# Altium schematic sheet ESP32.SchDoc
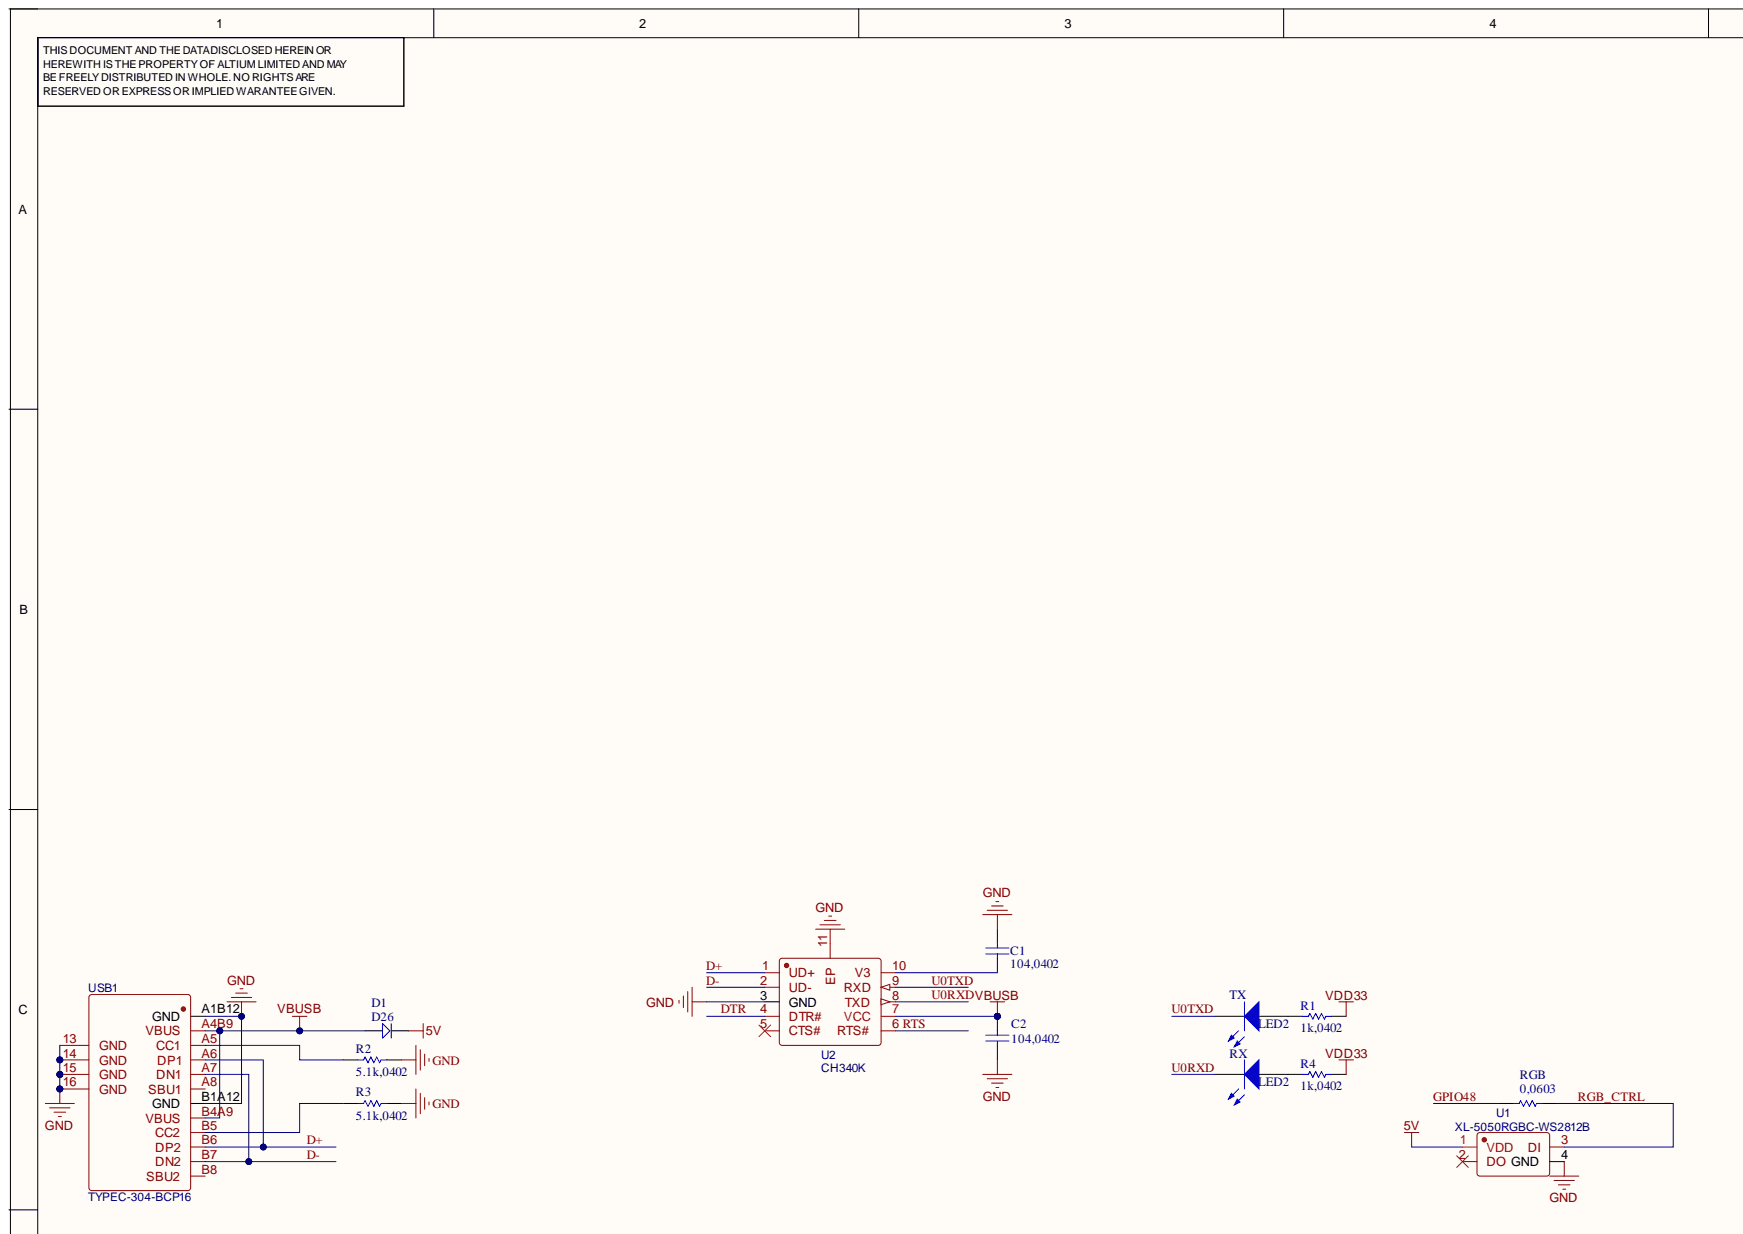
[diagram p0: ESP32.SchDoc, top left]
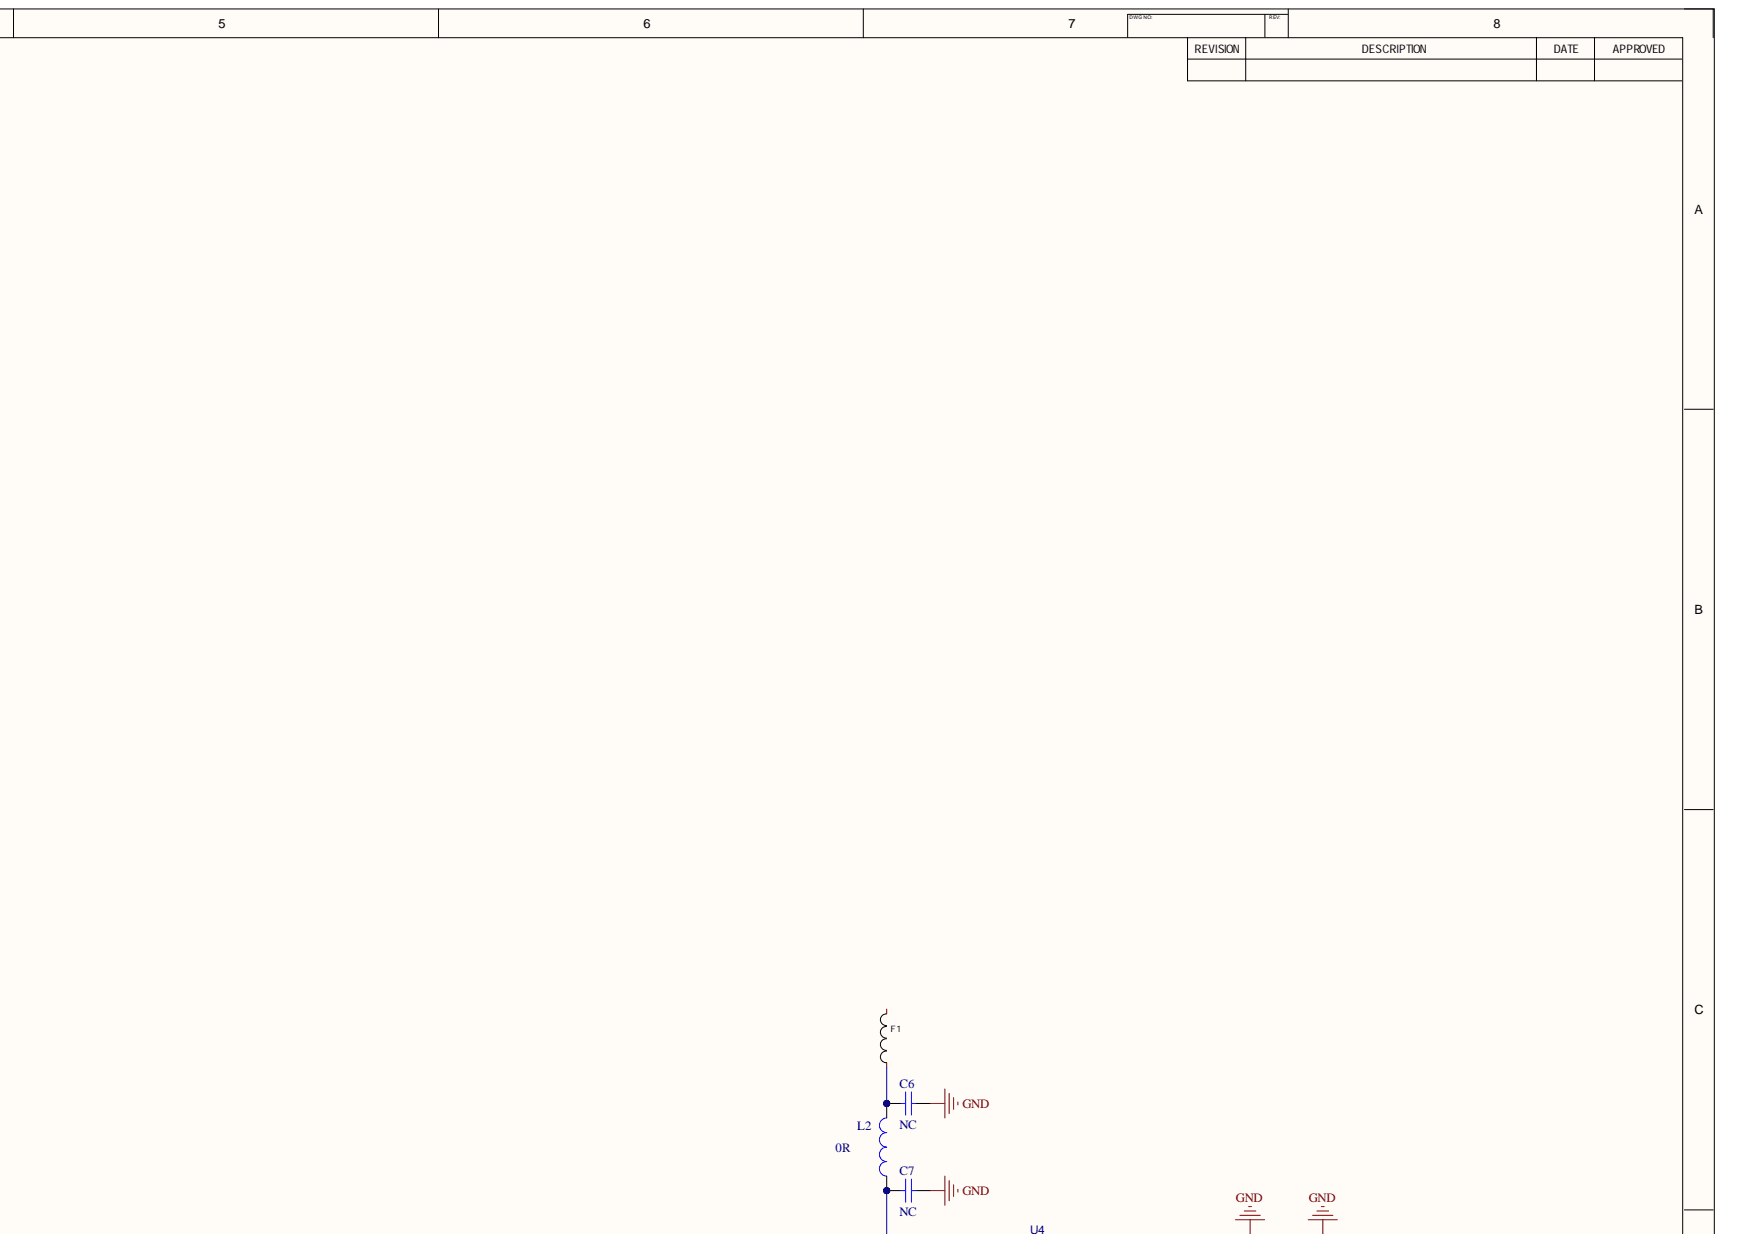
[diagram p1: ESP32.SchDoc, top right]
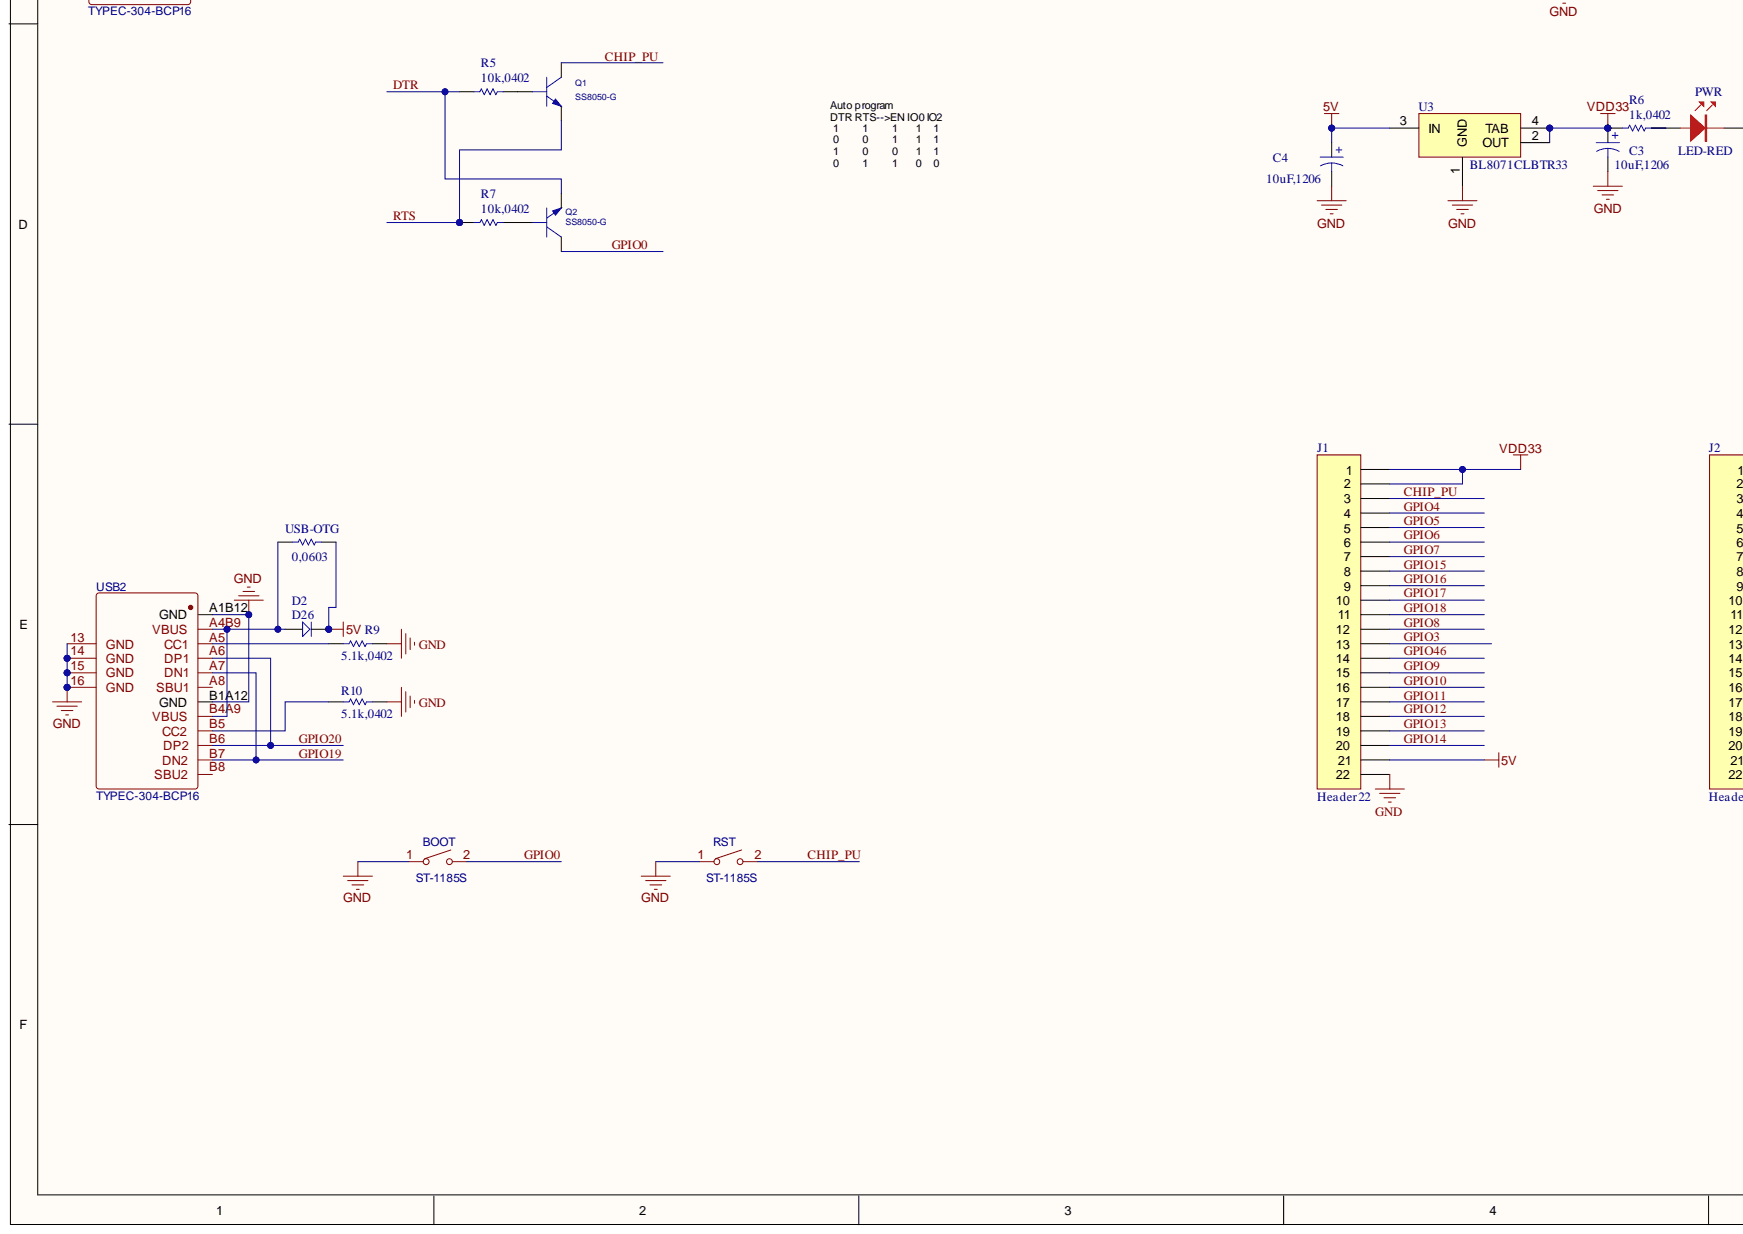
[diagram p2: ESP32.SchDoc, bottom left]
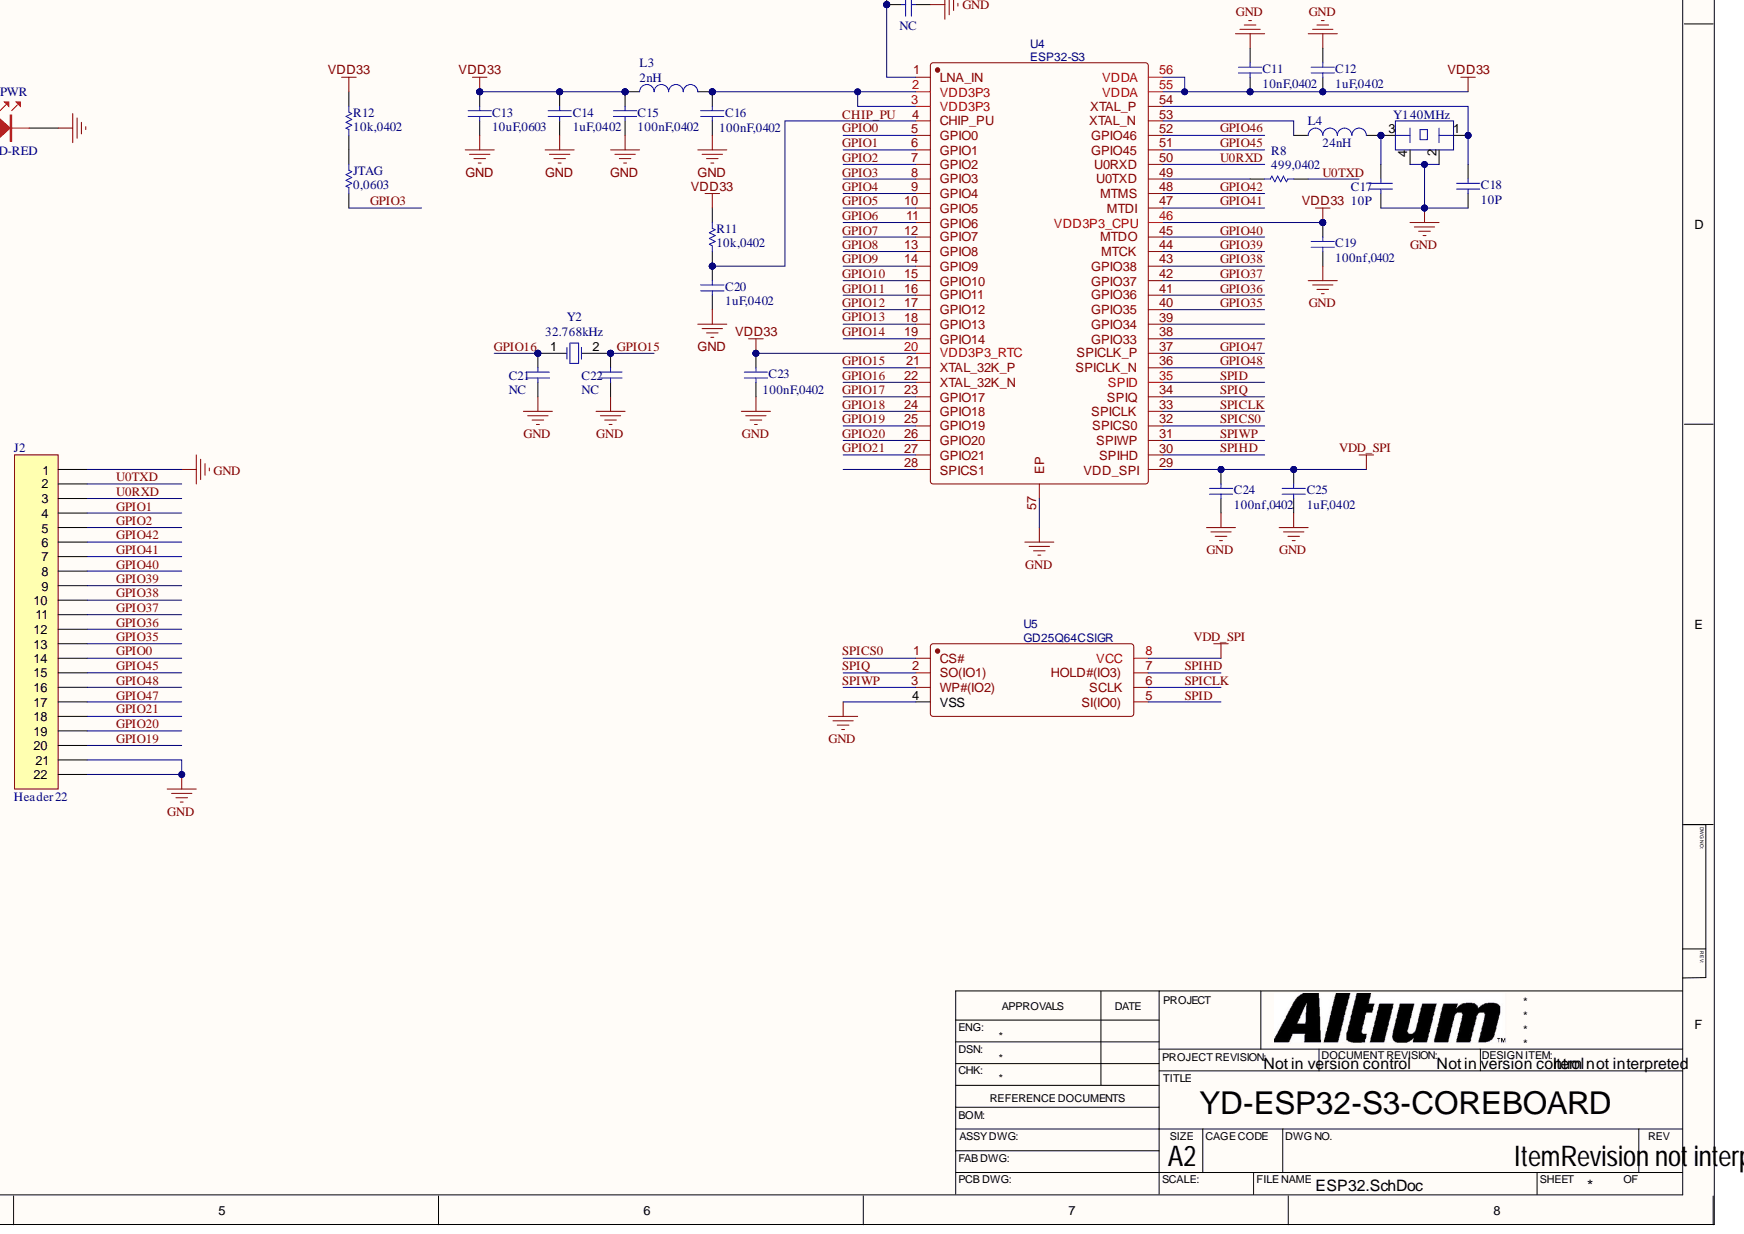
[diagram p3: ESP32.SchDoc, bottom right]

## Parts
BOOT  ST-1185S  [SW-SMD_ST-1185S]
C1  104,0402  [C0402]
C2  104,0402  [C0402]
C3  10uF,1206  [A]
C4  10uF,1206  [a]
C6  NC  [C0402]
C7  NC  [C0402]
C11  10nF,0402  [C0402]
C12  1uF,0402  [C0402]
C13  10uF,0603  [C0603]
C14  1uF,0402  [C0402]
C15  100nF,0402  [C0402]
C16  100nF,0402  [C0402]
C17  10P  [C0402]
C18  10P  [C0402]
C19  100nf,0402  [C0402]
C20  1uF,0402  [C0402]
C21  NC  [C0402]
C22  NC  [C0402]
C23  100nF,0402  [C0402]
C24  100nf,0402  [C0402]
C25  1uF,0402  [C0402]
D1  D26  [SOD-123FL]
D2  D26  [SOD-123FL]
F1  RFANT5220110A2T  [ANT-SMD_L5.2-W2.0]  (value not drawn)
J1  Header 22  [HDR1X22]
J2  Header 22  [HDR1X22]
JTAG  0,0603  [SB_0603]
L2  value 0R  [R0402]  (hidden comment: Inductor)
L3  value 2nH  [R0402]  (hidden comment: Inductor)
L4  value 24nH  [R0402]  (hidden comment: Inductor)
PWR  LED-RED  [LED0603]
Q1  SS8050-G  [SOT23]
Q2  SS8050-G  [SOT23]
R1  1k,0402  [R0402]
R2  5.1k,0402  [R0402]
R3  5.1k,0402  [R0402]
R4  1k,0402  [R0402]
R5  10k,0402  [R0402]
R6  1k,0402  [R0402]
R7  10k,0402  [R0402]
R8  499,0402  [R0402]
R9  5.1k,0402  [R0402]
R10  5.1k,0402  [R0402]
R11  10k,0402  [R0402]
R12  10k,0402  [R0402]
RGB  0,0603  [SB_0603]
RST  ST-1185S  [SW-SMD_ST-1185S]
RX  LED2  [LED0603]
TX  LED2  [LED0603]
U1  XL-5050RGBC-WS2812B  [LED-SMD_4P-L5.0-W5.0-BL_XL-5050RGBC]
U2  CH340K  [ESOP-10_L4.9-W3.9-P1.00-LS6.2-BL-EP]
U3  BL8071CLBTR33  [SOT-23]
U4  ESP32-S3  [QFN-56_L7.0-W7.0-P0.40-TL-EP4.0]
U5  GD25Q64CSIGR  [SOP-8_L5.3-W5.3-P1.27-LS8.0-BL]
USB1  TYPEC-304-BCP16  [USB-C-SMD_TYPEC-304-BCP16]
USB2  TYPEC-304-BCP16  [USB-C-SMD_TYPEC-304-BCP16]
USB-OTG  0,0603  [SB_0603]
Y1  40MHz  [EPSON_TSX-3225]
Y2  32.768kHz  [FC-135R_L3.2-W1.5]

## Nets
5V: C4.1 D1.2 D2.2 J1.21 U1.1(VDD) U3.3(IN) USB-OTG.1
GND: BOOT.1 C1.2 C2.2 C3.2 C4.2 C6.1 C7.1 C11.2 C12.2 C13.1 C14.1 C15.1 C16.1 C17.1 C18.1 C19.1 C20.1 C21.1 C22.1 C23.1 C24.1 C25.1 J1.22 J2.1 J2.21 J2.22 PWR.K R2.2 R3.2 R9.2 R10.2 RST.1 U1.4(GND) U2.3(GND) U2.11(EP) U3.1(GND) U4.57(EP) U5.4(VSS) USB1.13(GND) USB1.14(GND) USB1.15(GND) USB1.16(GND) USB1.A1B12(GND) USB1.B1A12(GND) USB2.13(GND) USB2.14(GND) USB2.15(GND) USB2.16(GND) USB2.A1B12(GND) USB2.B1A12(GND) Y1.2 Y1.4
VBUSB: C2.1 D1.1 U2.7(VCC) USB1.A4B9(VBUS) USB1.B4A9(VBUS)
VDD33: C3.1 C11.1 C12.1 C13.2 C14.2 C15.2 C19.2 C23.2 J1.1 J1.2 L3.1 R1.2 R4.2 R6.2 R11.1 R12.1 U3.2(OUT) U3.4(TAB) U4.20(VDD3P3_RTC) U4.46(VDD3P3_CPU) U4.55(VDDA) U4.56(VDDA)
VDD_SPI: C24.2 C25.2 U4.29(VDD_SPI) U5.8(VCC)
CHIP_PU: C20.2 J1.3 Q1.3 R11.2 RST.2 U4.4(CHIP_PU)
D+: U2.1(UD+) USB1.A6(DP1) USB1.B6(DP2)
D-: U2.2(UD-) USB1.A7(DN1) USB1.B7(DN2)
DTR: Q2.2 R5.2 U2.4(DTR#)
GPIO0: BOOT.2 J2.14 Q2.3 U4.5(GPIO0)
GPIO1: J2.4 U4.6(GPIO1)
GPIO2: J2.5 U4.7(GPIO2)
GPIO3: J1.13 JTAG.2 U4.8(GPIO3)
GPIO4: J1.4 U4.9(GPIO4)
GPIO5: J1.5 U4.10(GPIO5)
GPIO6: J1.6 U4.11(GPIO6)
GPIO7: J1.7 U4.12(GPIO7)
GPIO8: J1.12 U4.13(GPIO8)
GPIO9: J1.15 U4.14(GPIO9)
GPIO10: J1.16 U4.15(GPIO10)
GPIO11: J1.17 U4.16(GPIO11)
GPIO12: J1.18 U4.17(GPIO12)
GPIO13: J1.19 U4.18(GPIO13)
GPIO14: J1.20 U4.19(GPIO14)
GPIO15: C22.2 J1.8 U4.21(XTAL_32K_P) Y2.2
GPIO16: C21.2 J1.9 U4.22(XTAL_32K_N) Y2.1
GPIO17: J1.10 U4.23(GPIO17)
GPIO18: J1.11 U4.24(GPIO18)
GPIO19: J2.20 U4.25(GPIO19) USB2.A7(DN1) USB2.B7(DN2)
GPIO20: J2.19 U4.26(GPIO20) USB2.A6(DP1) USB2.B6(DP2)
GPIO21: J2.18 U4.27(GPIO21)
GPIO35: J2.13 U4.40(GPIO35)
GPIO36: J2.12 U4.41(GPIO36)
GPIO37: J2.11 U4.42(GPIO37)
GPIO38: J2.10 U4.43(GPIO38)
GPIO39: J2.9 U4.44(MTCK)
GPIO40: J2.8 U4.45(MTDO)
GPIO41: J2.7 U4.47(MTDI)
GPIO42: J2.6 U4.48(MTMS)
GPIO45: J2.15 U4.51(GPIO45)
GPIO46: J1.14 U4.52(GPIO46)
GPIO47: J2.17 U4.37(SPICLK_P)
GPIO48: J2.16 RGB.2 U4.36(SPICLK_N)
RGB_CTRL: RGB.1 U1.3(DI)
RTS: Q1.2 R7.2 U2.6(RTS#)
SPICLK: U4.33(SPICLK) U5.6(SCLK)
SPICS0: U4.32(SPICS0) U5.1(CS#)
SPID: U4.35(SPID) U5.5(SI(IO0))
SPIHD: U4.30(SPIHD) U5.7(HOLD#(IO3))
SPIQ: U4.34(SPIQ) U5.2(SO(IO1))
SPIWP: U4.31(SPIWP) U5.3(WP#(IO2))
U0RXD: J2.3 RX.2 U2.8(TXD) U4.50(U0RXD)
U0TXD: J2.2 R8.1 TX.2 U2.9(RXD)
NetC1_1: C1.1 U2.10(V3)
NetC6_2: C6.2 F1.1 L2.2
NetC7_2: C7.2 L2.1 U4.1(LNA_IN)
NetC16_2: C16.2 L3.2 U4.2(VDD3P3) U4.3(VDD3P3)
NetC17_2: C17.2 L4.2 Y1.3
NetC18_2: C18.2 U4.54(XTAL_P) Y1.1
NetD2_1: D2.1 USB2.A4B9(VBUS) USB2.B4A9(VBUS) USB-OTG.2
NetJTAG_1: JTAG.1 R12.2
NetL4_1: L4.1 U4.53(XTAL_N)
NetPWR_A: PWR.A R6.1
NetQ1_1: Q1.1 R5.1
NetQ2_1: Q2.1 R7.1
NetR1_1: R1.1 TX.1
NetR2_1: R2.1 USB1.A5(CC1)
NetR3_1: R3.1 USB1.B5(CC2)
NetR4_1: R4.1 RX.1
NetR8_2: R8.2 U4.49(U0TXD)
NetR9_1: R9.1 USB2.A5(CC1)
NetR10_1: R10.1 USB2.B5(CC2)

Not connected: F1 2; U1 2(DO); U2 5(CTS#); U4 28(SPICS1) 38(GPIO33) 39(GPIO34); USB1 A8(SBU1) B8(SBU2); USB2 A8(SBU1) B8(SBU2)

## Library data of the parts
BOOT RST = ST-1185S: BOM_Manufacturer: RI SHENG; Contributor: LCSC; BOM_JLCPCB Part Class: Extended Part; BOM_Manufacturer Part: ST-1185S; BOM_Supplier: LCSC; BOM_Supplier Part: C589191; Component Kind: Standard; spicePre: S; spiceSymbolName: ST-1185S
C1 C2 C6 C7 C11-C16 C19 C20 C23-C25 = Cap: Capacitor; Value: 10; Component Kind: Standard; LatestRevisionDate: 17-Jul-2002; LatestRevisionNote: Re-released for DXP Platform.; Library Name: Miscellaneous Devices.IntLib; Published: 8-Jun-2000; Signal Integrity: Cap; Simulation: CAP; Publisher: Altium Limited
C3 C4 = Cap Pol1: Polarized Capacitor (Radial); Component Kind: Standard; LatestRevisionDate: 17-Jul-2002; LatestRevisionNote: Re-released for DXP Platform.; Library Name: Miscellaneous Devices.IntLib; PackageReference: RB7.6-15; Published: 8-Jun-2000; Publisher: Altium Limited
C17 C18 C21 C22 = Cap: Capacitor; Value: 104; Component Kind: Standard; LatestRevisionDate: 17-Jul-2002; LatestRevisionNote: Re-released for DXP Platform.; Library Name: Miscellaneous Devices.IntLib; Published: 8-Jun-2000; Signal Integrity: Cap; Simulation: CAP; Publisher: Altium Limited
D1 D2 = D: 1N4148; Component Kind: Standard; Library Name: SIM900A.SchLib
F1 = RFANT5220110A2T: Manufacturer: Walsin(华新科); Add into BOM: yes; Component Kind: Standard; Convert to PCB: yes; Datasheet: https://atta.szlcsc.com/upload/public/pdf/source/20180726/C201761_362CD995DDC5DCD6FABE652FDC161BB4.pdf; Device: RFANT5220110A2T; JLCPCB Part Class: Extended Part; LCSC Part Name: RFANT5220110A2T; Manufacturer Part: RFANT5220110A2T; Name: RFANT5220110A2T; PCB3D: ANT-SMD_L5.2-W2.0-H1.1; Supplier: LCSC; Supplier Footprint: SMD,5.2x2x1.1mm; Supplier Part: C201761; Symbol: RFANT5220110A2T
J1 J2 = Header 22: Header, 22-Pin; Component Kind: Standard; LatestRevisionDate: 17-Jul-2002; LatestRevisionNote: Re-released for DXP Platform.; Library Name: Miscellaneous Connectors.IntLib; Signal Integrity: Connector; Publisher: Altium Limited
JTAG R2 R3 R6 R8-R10 RGB USB-OTG = Resistor_ST: Resistor 0402 / 0603 / 0805; Value: 10K; Component Kind: Standard; Library Name: MCD_TOOLS.SchLib
L2 L4 = Inductor: Code_IEC: 1005; Code_JEITA: 0402; Component Kind: Standard; Description: Inductor; LatestRevisionDate: 29-May-2009; LatestRevisionNote: IPC-7351 Footprint Added.; Library Name: Miscellaneous Devices.IntLib; PackageDescription: Chip Inductor; PackageReference: 0402-A; Published: 8-Jun-2000; Signal Integrity: Inductor; Simulation: INDUCTOR; Publisher: Altium Limited
L3 = Inductor: 2nH ±0.2nH 900mA 70mΩ MLG1005S2N0CT000; Code_IEC: 1005; Code_JEITA: 0402; Component Kind: Standard; LatestRevisionDate: 29-May-2009; LatestRevisionNote: IPC-7351 Footprint Added.; Library Name: Miscellaneous Devices.IntLib; PackageDescription: Chip Inductor; PackageReference: 0402-A; Published: 8-Jun-2000; Signal Integrity: Inductor; Simulation: INDUCTOR; Publisher: Altium Limited
Q1 Q2 = NPN BCE_0: PspiceTemplate: Q^@REFDES %C %B %E; Component Kind: Standard; Simulation: NPN BCE_0
R1 R4 = Res1: Resistor; Value: 100; Component Kind: Standard; LatestRevisionDate: 17-Jul-2002; LatestRevisionNote: Re-released for DXP Platform.; Library Name: Miscellaneous Devices.IntLib; PackageReference: AXIAL-0.3; Published: 8-Jun-2000; Signal Integrity: Res; Simulation: RESISTOR; Publisher: Altium Limited
R5 R7 R11 R12 = Resistor_ST: Resistor 0402 / 0603 / 0805; Component Kind: Standard; Library Name: MCD_TOOLS.SchLib; Value: 10K
RX TX = LED2: Typical RED, GREEN, YELLOW, AMBER GaAs LED; Component Kind: Standard; LatestRevisionDate: 17-Jul-2002; LatestRevisionNote: Re-released for DXP Platform.; Library Name: Miscellaneous Devices.IntLib; PackageDocument: Feb-2002; PackageReference: 3.2X1.6X1.1; Published: 8-Jun-2000; Simulation: LED2; Publisher: Altium Limited
U1 = XL-5050RGBC-WS2812B: BOM_Manufacturer: XINGLIGHT(成兴光); Contributor: LCSC; BOM_JLCPCB Part Class: Extended Part; BOM_Manufacturer Part: XL-5050RGBC-WS2812B; BOM_Supplier: LCSC; BOM_Supplier Part: C2843785; Component Kind: Standard; spicePre: L; spiceSymbolName: XL-5050RGBC-WS2812B
U2 = CH340K: BOM_Manufacturer: WCH(Jiangsu Qin Heng); BOM_Paste Type: expand; Contributor: LCSC; BOM_Manufacturer Part: CH340K; BOM_Supplier: LCSC; BOM_Supplier Part: C968586; Component Kind: Standard; spicePre: U; spiceSymbolName: CH340K
U3 = REG1117-3.3: 800mA and 1A Low Dropout Positive Regulator 2.85V, 3.3V, 5V, and Adjustable; Component Kind: Standard; ComponentLink1Description: Manufacturer Link; ComponentLink1URL: http://www.ti.com/; ComponentLink2Description: Datasheet; ComponentLink2URL: http://www-s.ti.com/sc/ds/REG1117.pdf; DatasheetVersion: Sep-1997; LatestRevisionDate: 17-Jul-2002; LatestRevisionNote: Re-released for DXP Platform.; Library Name: ESP32.SchLib; PackageDescription: SOT-223/SC-73; 4 Leads; Body 6.7 x 7.3 mm, inc. leads (LxW max); PackageReference: ZZ311; PackageVersion: revC; Published: 8-Jun-2000; Publisher: Altium Limited
U4 = ESP32-S3: BOM_Manufacturer: ESPRESSIF 乐鑫; Contributor: LCSC; BOM_JLCPCB Part Class: Extended Part; BOM_Manufacturer Part: ESP32-S3; BOM_Supplier: LCSC; BOM_Supplier Part: C2913192; Component Kind: Standard; spicePre: U; spiceSymbolName: ESP32-S3
U5 = GD25Q64CSIGR: BOM_Manufacturer: GigaDevice Semicon Beijing; Contributor: LCSC; BOM_JLCPCB Part Class: Extended Part; BOM_Manufacturer Part: GD25Q64CSIGR; BOM_Supplier: LCSC; BOM_Supplier Part: C395510; Component Kind: Standard; spicePre: U; spiceSymbolName: GD25Q64CSIGR
USB1 USB2 = TYPEC-304-BCP16: BOM_Manufacturer: XUNPU; Contributor: LCSC; BOM_Manufacturer Part: TYPEC-304-BCP16; BOM_Supplier: LCSC; BOM_Supplier Part: C720629; Component Kind: Standard; spicePre: U; spiceSymbolName: TYPEC-304-BCP16
Y1 = CRYSTAL: Component Kind: Standard; Library Name: YD-Pyboard.SchLib
Y2 = XTAL: Component Kind: Standard; LatestRevisionDate: 17-Jul-2002; LatestRevisionNote: Re-released for DXP Platform.; Library Name: Miscellaneous Devices.IntLib; Published: 8-Jun-2000; Simulation: XTAL; Publisher: Altium Limited
PWR = LED-RED: Component Kind: Standard; Library Name: YD-Pyboard.SchLib
